# Altium schematic sheet IDC_Tester_v1_LED_6.SchDoc(IDC_Tester_v1_LED_6)
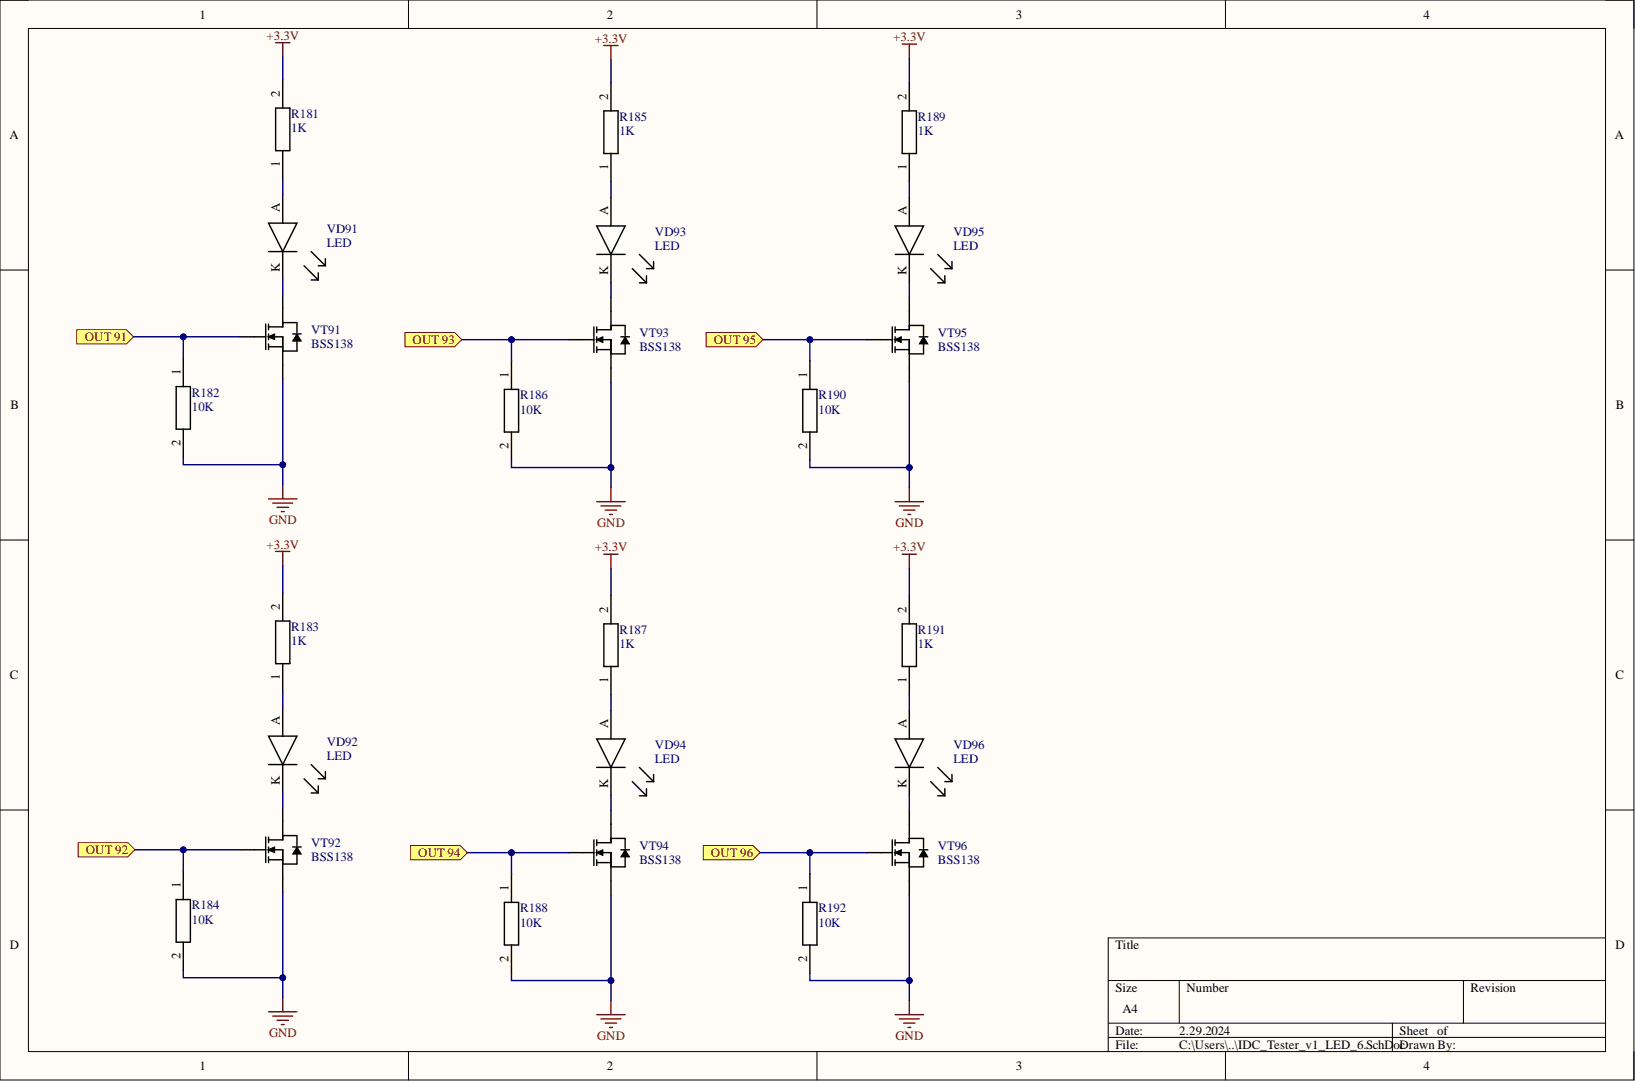
[diagram p0: IDC_Tester_v1_LED_6.SchDoc]

## Parts
R181  1K  [Resistor 0603]
R182  10K  [Resistor 0603]
R183  1K  [Resistor 0603]
R184  10K  [Resistor 0603]
R185  1K  [Resistor 0603]
R186  10K  [Resistor 0603]
R187  1K  [Resistor 0603]
R188  10K  [Resistor 0603]
R189  1K  [Resistor 0603]
R190  10K  [Resistor 0603]
R191  1K  [Resistor 0603]
R192  10K  [Resistor 0603]
VD91  LED  [Led 0603 - duplicate]
VD92  LED  [Led 0603 - duplicate]
VD93  LED  [Led 0603 - duplicate]
VD94  LED  [Led 0603 - duplicate]
VD95  LED  [Led 0603 - duplicate]
VD96  LED  [Led 0603 - duplicate]
VT91  BSS138  [SOT-23-3]
VT92  BSS138  [SOT-23-3]
VT93  BSS138  [SOT-23-3]
VT94  BSS138  [SOT-23-3]
VT95  BSS138  [SOT-23-3]
VT96  BSS138  [SOT-23-3]

## Ports
OUT 91, OUT 92, OUT 93, OUT 94, OUT 95, OUT 96

## Nets
+3.3V: R181.2 R183.2 R185.2 R187.2 R189.2 R191.2
GND: R182.2 R184.2 R186.2 R188.2 R190.2 R192.2 VT91.2 VT92.2 VT93.2 VT94.2 VT95.2 VT96.2
OUT 91: R182.1 VT91.1 ; port OUT 91
OUT 92: R184.1 VT92.1 ; port OUT 92
OUT 93: R186.1 VT93.1 ; port OUT 93
OUT 94: R188.1 VT94.1 ; port OUT 94
OUT 95: R190.1 VT95.1 ; port OUT 95
OUT 96: R192.1 VT96.1 ; port OUT 96
NetR181_1: R181.1 VD91.A
NetR183_1: R183.1 VD92.A
NetR185_1: R185.1 VD93.A
NetR187_1: R187.1 VD94.A
NetR189_1: R189.1 VD95.A
NetR191_1: R191.1 VD96.A
NetVD91_K: VD91.K VT91.3
NetVD92_K: VD92.K VT92.3
NetVD93_K: VD93.K VT93.3
NetVD94_K: VD94.K VT94.3
NetVD95_K: VD95.K VT95.3
NetVD96_K: VD96.K VT96.3

## Library data of the parts
R181 R183 R185 R187 R189 R191 = Resistor 1K: Part Number: SMD RES 1K OHM 1%±100ppm/°C 0603 (RMCF0603FT1K00); Added by: viskov2055@gmail.com; Mount_Type: SMD; Pkg: 0603; Solder_Amount: 2; Component Kind: Standard; Created at: 13/02/2024; Mount: MOUNT; Library Name: Schlib_IDC_Tester_v1.SchLib
R182 R184 R186 R188 R190 R192 = Resistor 10K: Part Number: SMD RES 10K OHM 1%±100ppm/°C 0603 (RMCF0603FT10K0); Added by: viskov2055@gmail.com; Mount_Type: SMD; Pkg: 0603; Solder_Amount: 2; Component Kind: Standard; Created at: 15/02/2024; Mount: MOUNT; Library Name: Schlib_IDC_Tester_v1.SchLib
VD91-VD96 = LED: LED Uni-Color Green 515nm 2-Pin SMD T/R; Manufacturer: Kingbright; Manufacturer Part Number: APG1608ZGCK; Added by: viskov2055@gmail.com; Dominant Wavelength: 525nm; Forward Voltage: 3.3V; Lens Size: 0.8mm; Lens Style: Flat; Lens Transparency: Clear; Luminous Intensity: 400mcd; Pkg: 0603; Solder_Amount: 2; Test Current: 20mA; Viewing Angle: 130°; Case Code (Imperial): 0603; Case Code (Metric): 1608; Case/Package: 0603; Category: LEDs; Color: Green; Component Kind: Standard; ComponentLink1Description: https://datasheet.octopart.com/APG1608ZGCK-Kingbright-datasheet-153682089.pdf?src-supplier=Future+Electronics; ComponentLink1URL: https://datasheet.octopart.com/APG1608ZGCK-Kingbright-datasheet-153682089.pdf?src-supplier=Future+Electronics; ComponentLink2Description: http://www.kingbrightusa.com/images/catalog/SPEC/APG1608ZGCK.pdf?src-supplier=Digikey; ComponentLink2URL: http://www.kingbrightusa.com/images/catalog/SPEC/APG1608ZGCK.pdf?src-supplier=Digikey; ComponentLink3Description: https://datasheet.octopart.com/APG1608ZGCK-Kingbright-datasheet-137408059.pdf?src-supplier=Sager+Electronics; ComponentLink3URL: https://datasheet.octopart.com/APG1608ZGCK-Kingbright-datasheet-137408059.pdf?src-supplier=Sager+Electronics; Created at: 13/02/2024; Height: 0.28mm; Length: 1.6mm; Mount: MOUNT; MountType: SMD; Packaging: Tape and Reel; Part Number: APG1608ZGCK; Pricing 1: 1=0,6, 10=0,368, 100=0,2114, 1000=0,16544, 2000=0,15165 (USD); RoHS: Yes; RoHS Compliant: Yes; Stock 1: 44996; Supplier 1: Digikey; Supplier Part Number 1: 754-2113-1-ND; Width: 0.8mm; Library Name: Schlib_IDC_Tester_v1.SchLib
VT91-VT96 = BSS138: N-Channel Logic Level Enhancement Mode Field Effect Transistor; Added_by: ma_lubushkin@teslatec.ru; Pkg: SOT-23; Solder_Amount: 3; Component Kind: Standard; Created_at: 03/12/2019; Mount: MOUNT; MountType: SMD; Part Number: BSS138; Library Name: Schlib_IDC_Tester_v1.SchLib
